# Altium schematic sheet Crystal_oscillator.SchDoc
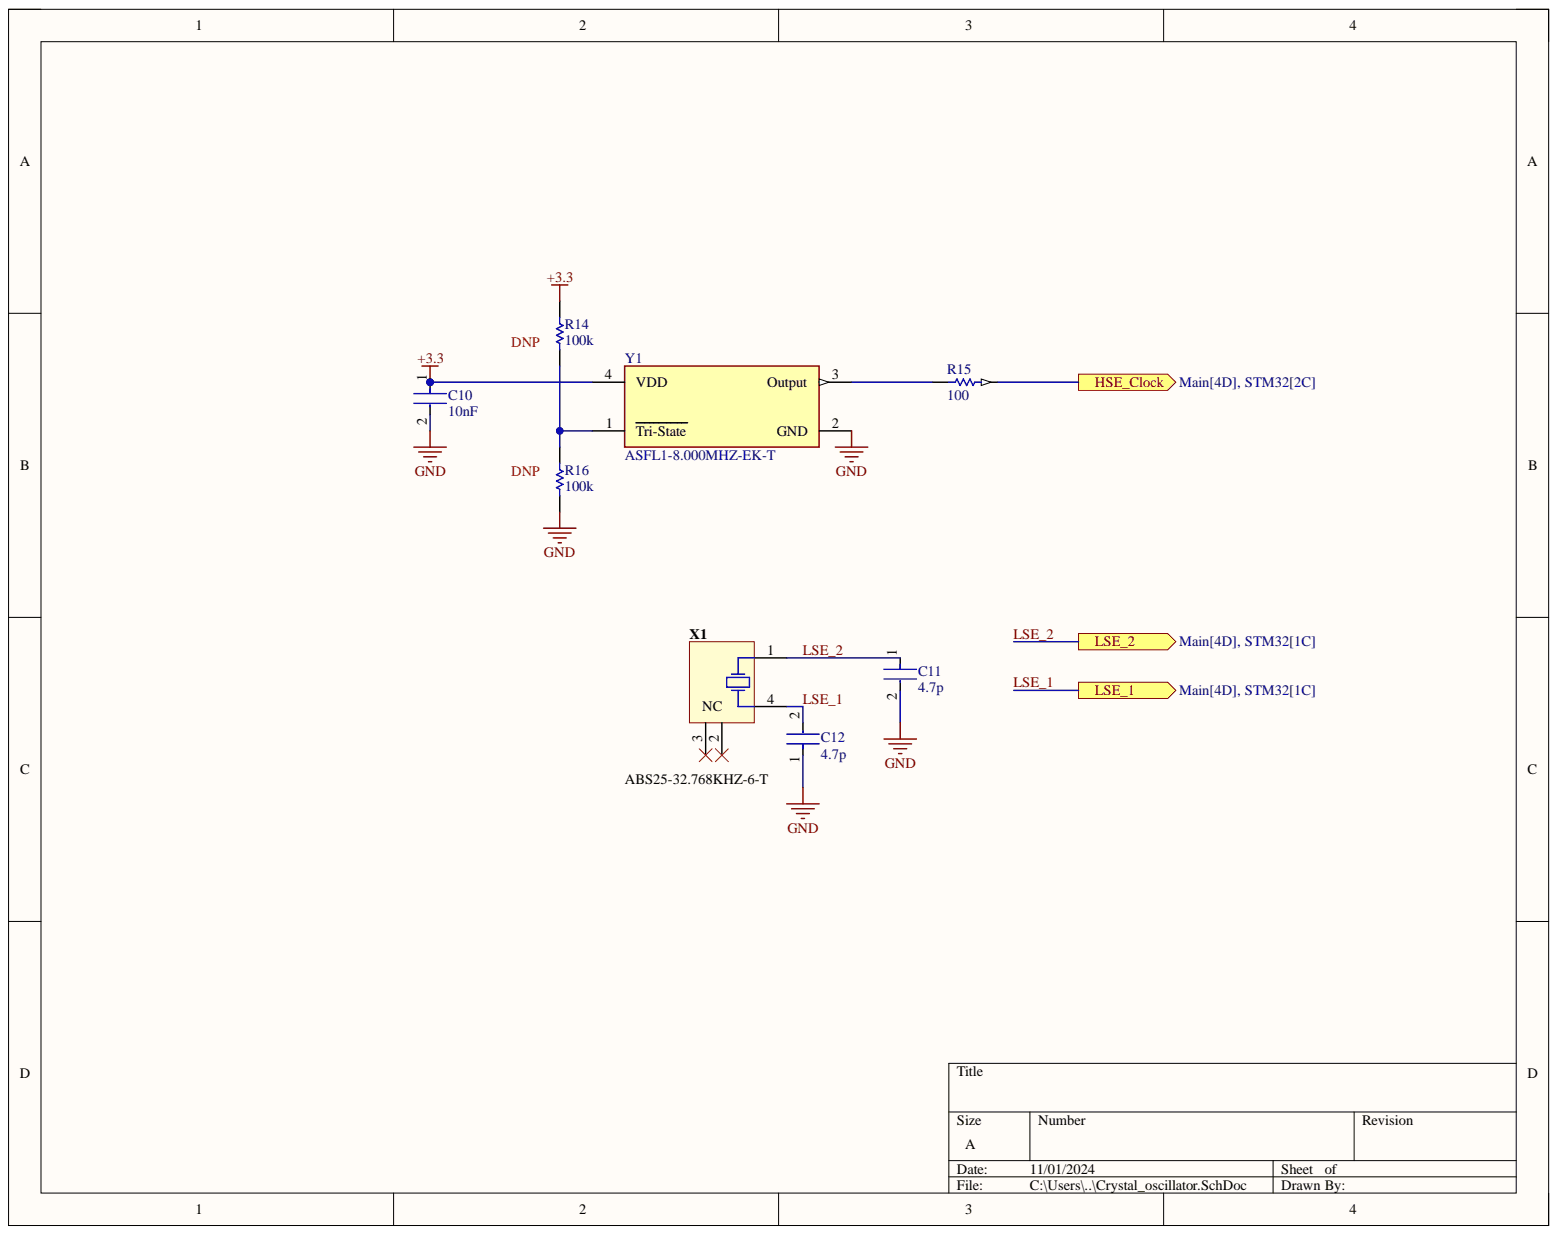
[diagram p0: Crystal_oscillator.SchDoc]

## Parts
C10  10nF  [FP-0805-L_2_01_0_2-W_1_25-IPC_B]
C11  4.7p  [FP-C0603C-CF-MFG]
C12  4.7p  [FP-C0603C-CF-MFG]
R14  100k  [RESC2013X50X30LL20T20]
R15  100  [RESC2013X50X30LL20T20]
R16  100k  [RESC2013X50X30LL20T20]
X1  ABS25-32.768KHZ-6-T  [ABRA-ABS25-4_V]
Y1  ASFL1-8.000MHZ-EK-T  [FP-ASFL1-MFG]

## Ports
HSE_Clock, LSE_1, LSE_2

## Nets
+3.3: C10.1 R14.2 Y1.4(VDD)
GND: C10.2 C11.2 C12.1 R16.1 Y1.2(GND)
HSE_Clock: R15.2 ; port HSE_Clock
LSE_1: C12.2 X1.4 ; port LSE_1
LSE_2: C11.1 X1.1 ; port LSE_2
NetR14_1: R14.1 R16.2 Y1.1(Tri-State)
NetR15_1: R15.1 Y1.3(Output)
NetX1_2: X1.2
NetX1_3: X1.3(NC)

## Library data of the parts
C10 = CMP-2007-04174-2: General Purpose Ceramic Capacitor, 0805, 10nF, 10%, X7R, 15%, 100V; Capacitance: 100nF; Case Code (Imperial): 0805; Case Code (Metric): 2012; Case/Package: 0805; Component Kind: Standard; Construction: Flat; Contact Plating: Tin; Depth: 1.25mm; Dielectric: X7R; Dielectric Material: Ceramic; Height: 1.4mm; Lead Free: Lead Free; Length: 2.01mm; Library Name: Altium Content Vault; Max Operating Temperature: 125°C; Min Operating Temperature: -55°C; Mount: Surface Mount; Number of Pins: 2; Package Quantity: 2000; Packaging: Tape and Reel; Radiation Hardening: No; REACH SVHC: No SVHC; RoHS Compliant: Yes; Series: -; Termination: SMD/SMT; Thickness: 0.055inch; Tolerance: 10%; Voltage: 100V; Voltage Rating: 100V; Voltage Rating (DC): 100V; Width: 0.049inch
C11 C12 = CMP-2006-03061-2: CAP CER 0.1UF 50V X7R 0603; Manufacturer: KEMET; Manufacturer Part Number: C0603C104K5RACTU; Automotive Grade: Grade 1; Capacitance: 0.10 μF; Material: Ceramic; Temperature Coefficient: 30ppm/°C; Tolerance: 0.1; Value: 0.10 μF; Automotive: Yes; Category: Cap; Component Kind: Standard; ComponentLink2Description: Datasheet; ComponentLink2URL: https://api.kemet.com/component-edge/download/datasheet/C0603C100J5GACAUTO.pdf; Device Class L1: Passive Components; Device Class L2: Capacitors; Device Class L3: Ceramic Capacitors; Digikey Description: CAP CER 0.1UF 50V X7R 0603; Height: 0.87mm; Lead Free: Yes; Library Name: Altium Content Vault; Octopart: https://octopart.com/c0603c104k5ractu-kemet-139244; Package: C0603C-CF; RoHS: TRUE; Temperature: -55°C to +125°C; Temperature Characteristic: X7R; Temperature Range High: +125°C; Temperature Range Low: -55°C; Voltage Rating: 50 V
R14-R16 = CMP-2001-04896-1: Manufacturer: Vishay Dale; Part Number: CRCW08050000Z0EA; Component Kind: Standard; Library Name: Altium Content Vault
X1 = CMP-2000-05036-1: Low Frequency Crystal, 32.768 KHz, 6 pF, +/- 20 ppm, -40 to 85 degC, 4-Pin SMD, RoHS, Tape and Reel; Brand: ABRACON; Case Height: 2.5mm; Case Length: 8mm; Case Width: 3.8mm; ESR: 50kOhms; Load Capacitance: 6pF; Tolerance: 20PPM; Component Kind: Standard; Datasheet URL: https://datasheet.ciiva.com/854/abs25-854528.pdf; Datasheet Version: Jun-17; Dimensions: 3.8mmWx8mmLx2.5mmH; Factory Pack Quantity: 3000; Frequency: 32.768kHz; Library Name: Altium Content Vault; Manufacturer: Abracon; Manufacturer URL: http://www.abracon.com/; Mounting Style: SMD/SMT; Operating Temperature Range: -40Cto+85C; Package / Case: 8mmx3.8mm; Package Description: 4-Pin Surface Mount Device, Body 8 x 3.8 mm, Pitch 5.5 mm; Package Reference: ABS25; Packaging: Reel; Product Category: Crystals; RoHS: Details; Series: ABS25; Termination Style: SMD/SMT; Type: LowFrequencyCrystal
Y1 = CMP-27762-013261-1: XTAL Oscillator 8MHz ±30ppm HCMOS, TTL 3.3V 4-SMD 5mm x 3.2mm; Load Capacitance: 15pF; Max Supply Current: 15mA; Max Supply Voltage: 3.63V; Min Supply Voltage: 2.97V; Case/Package: SMD/SMT; Component Kind: Standard; Depth: 3.2mm; Frequency: 8MHz; Frequency Stability: 30ppm; Height: 0.051inch; Lead Free: Lead Free; Length: 5mm; Library Name: Altium Content Vault; Max Operating Temperature: 70°C; Min Operating Temperature: -20°C; Mount: Surface Mount; Number of Pins: 4; Operating Frequency: 8 MHz; Operating Supply Voltage: 3.3V; Package Quantity: 1000; Packaging: Tape and Reel; Radiation Hardening: No; Series: ASFL1; Termination: SMD/SMT; Width: 0.126inch
